# Altium schematic sheet 07_FRONT_PANEL_CONTROL.SchDoc(07_FRONT_PANEL_CONTROL)
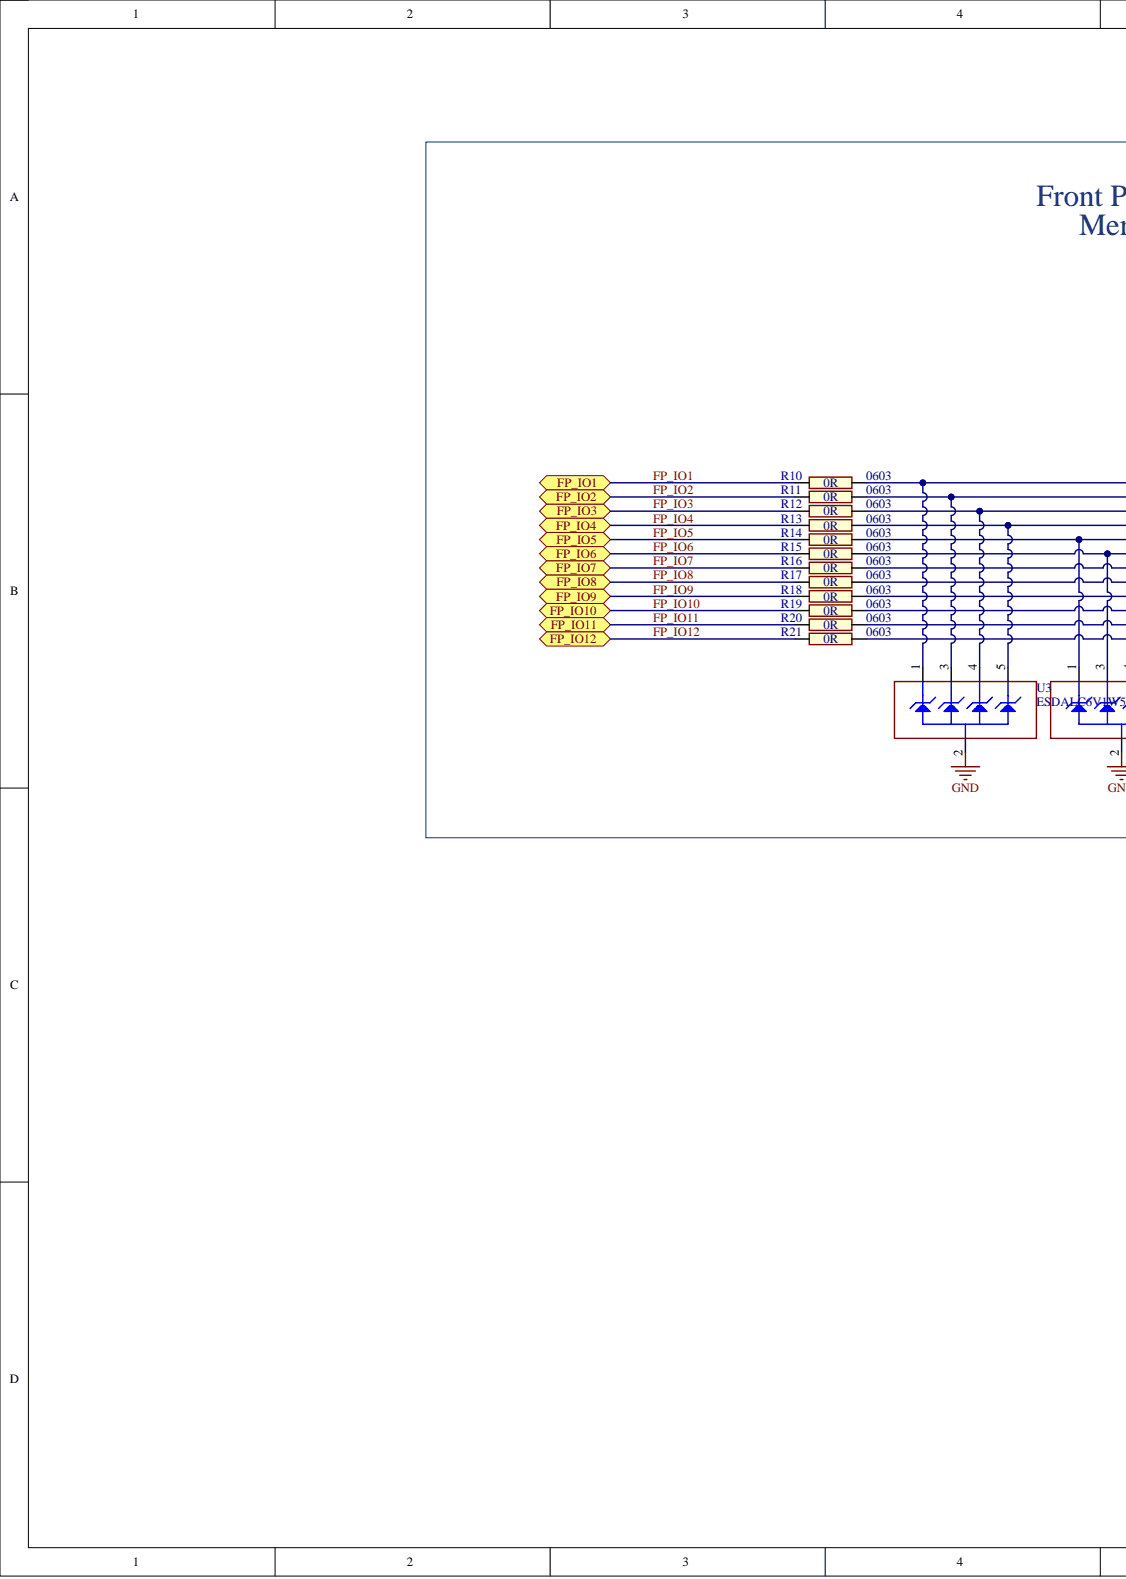
[diagram p0: 07_FRONT_PANEL_CONTROL.SchDoc, left]
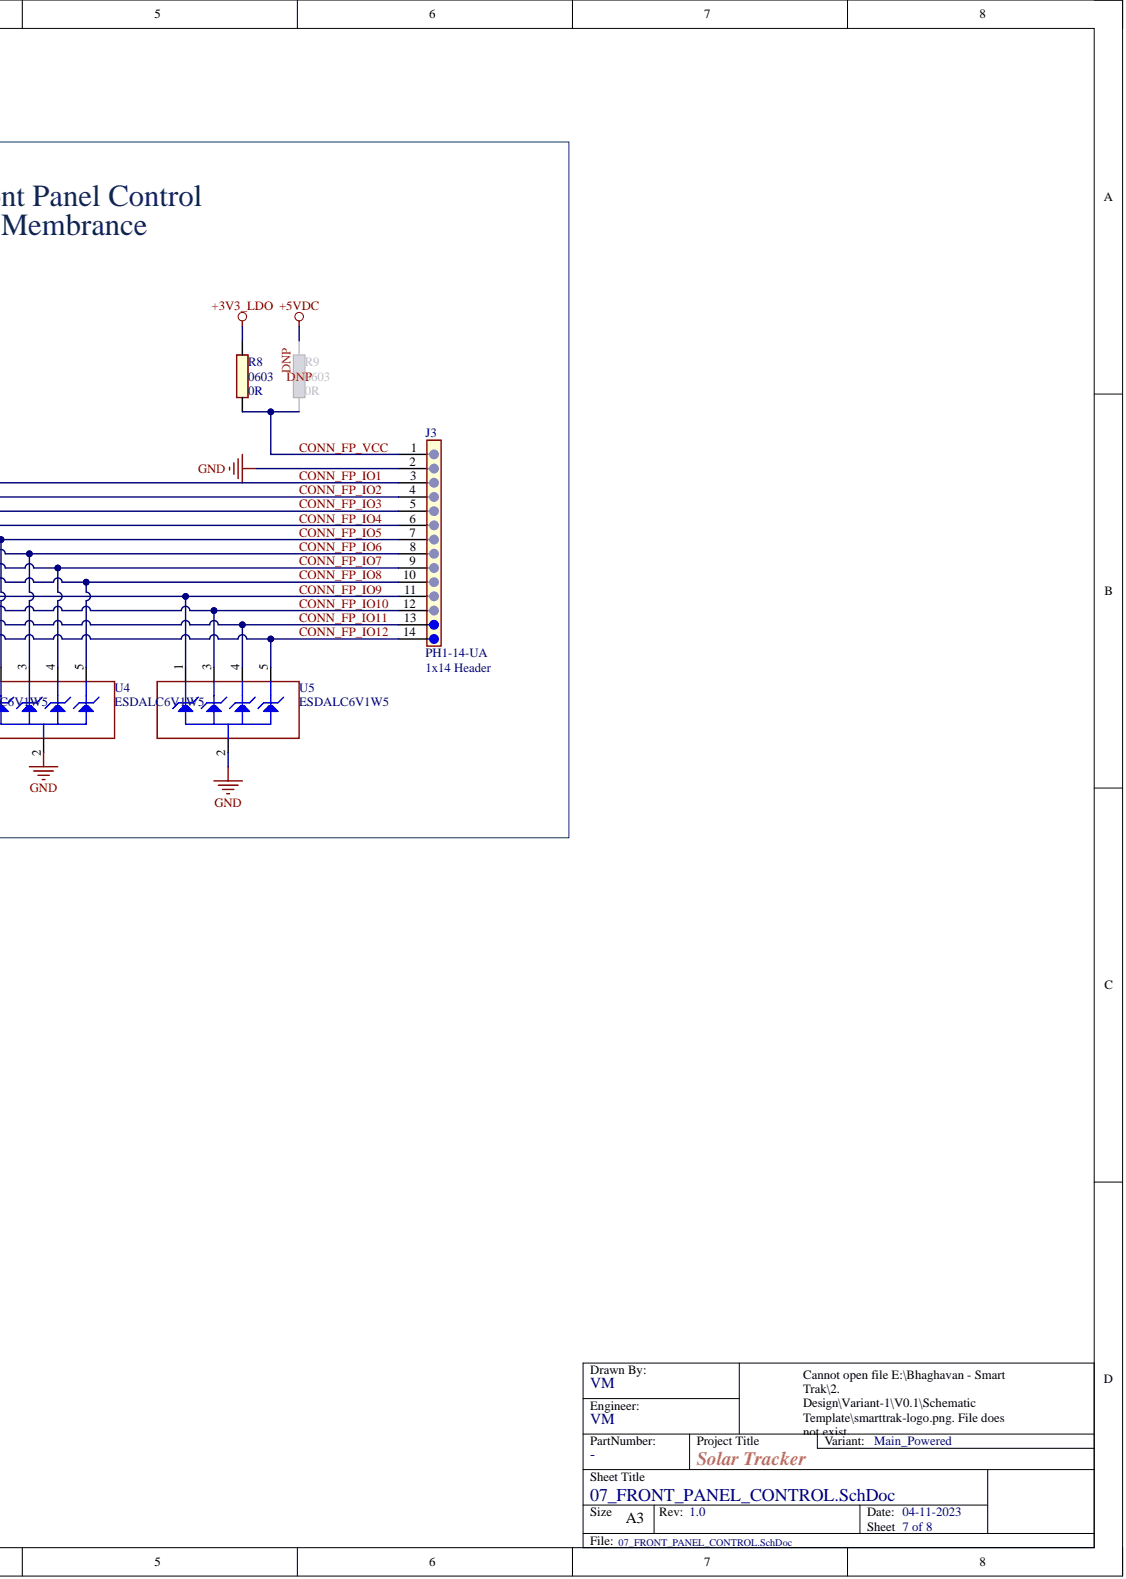
[diagram p1: 07_FRONT_PANEL_CONTROL.SchDoc, right]

## Parts
J3  value PH1-14-UA  Manufacturer: PH1-14-UA  Note: 1x14 Header  [BERG_1X14]  (hidden comment: HEADER_1x14_2.54MM)
R8  value 0R  Note: 0603  [R0603]  (hidden comment: RES_0R_0603)
R9  value 0R  Note: 0603  [R0603]  (hidden comment: RES_0R_0603)
R10  value 0R  Note: 0603  [R0603]  (hidden comment: RES_0R_0603)
R11  value 0R  Note: 0603  [R0603]  (hidden comment: RES_0R_0603)
R12  value 0R  Note: 0603  [R0603]  (hidden comment: RES_0R_0603)
R13  value 0R  Note: 0603  [R0603]  (hidden comment: RES_0R_0603)
R14  value 0R  Note: 0603  [R0603]  (hidden comment: RES_0R_0603)
R15  value 0R  Note: 0603  [R0603]  (hidden comment: RES_0R_0603)
R16  value 0R  Note: 0603  [R0603]  (hidden comment: RES_0R_0603)
R17  value 0R  Note: 0603  [R0603]  (hidden comment: RES_0R_0603)
R18  value 0R  Note: 0603  [R0603]  (hidden comment: RES_0R_0603)
R19  value 0R  Note: 0603  [R0603]  (hidden comment: RES_0R_0603)
R20  value 0R  Note: 0603  [R0603]  (hidden comment: RES_0R_0603)
R21  value 0R  Note: 0603  [R0603]  (hidden comment: RES_0R_0603)
U3  value ESDALC6V1W5  MPN: ESDALC6V1W5  [SOT65P210X110-5N]  (hidden comment: TVS_ESDALC6V1W5)
U4  value ESDALC6V1W5  MPN: ESDALC6V1W5  [SOT65P210X110-5N]  (hidden comment: TVS_ESDALC6V1W5)
U5  value ESDALC6V1W5  MPN: ESDALC6V1W5  [SOT65P210X110-5N]  (hidden comment: TVS_ESDALC6V1W5)

## Ports
FP_IO1, FP_IO2, FP_IO3, FP_IO4, FP_IO5, FP_IO6, FP_IO7, FP_IO8, FP_IO9, FP_IO10, FP_IO11, FP_IO12

## Nets
+3V3_LDO: R8.2
+5VDC: R9.2
GND: J3.2 U3.2 U4.2 U5.2
CONN_FP_IO1: J3.3 R10.2 U3.1
CONN_FP_IO2: J3.4 R11.2 U3.3
CONN_FP_IO3: J3.5 R12.2 U3.4
CONN_FP_IO4: J3.6 R13.2 U3.5
CONN_FP_IO5: J3.7 R14.2 U4.1
CONN_FP_IO6: J3.8 R15.2 U4.3
CONN_FP_IO7: J3.9 R16.2 U4.4
CONN_FP_IO8: J3.10 R17.2 U4.5
CONN_FP_IO9: J3.11 R18.2 U5.1
CONN_FP_IO10: J3.12 R19.2 U5.3
CONN_FP_IO11: J3.13 R20.2 U5.4
CONN_FP_IO12: J3.14 R21.2 U5.5
CONN_FP_VCC: J3.1 R8.1 R9.1
FP_IO1: R10.1 ; port FP_IO1
FP_IO2: R11.1 ; port FP_IO2
FP_IO3: R12.1 ; port FP_IO3
FP_IO4: R13.1 ; port FP_IO4
FP_IO5: R14.1 ; port FP_IO5
FP_IO6: R15.1 ; port FP_IO6
FP_IO7: R16.1 ; port FP_IO7
FP_IO8: R17.1 ; port FP_IO8
FP_IO9: R18.1 ; port FP_IO9
FP_IO10: R19.1 ; port FP_IO10
FP_IO11: R20.1 ; port FP_IO11
FP_IO12: R21.1 ; port FP_IO12

## Library data of the parts
J3 = HEADER_1x14_2.54MM: CONN HEADER VERT 14POS 2.54MM; MPN: Adam Tech; Component Kind: Standard; Library Name: Project.SchLib
R8-R21 = RES_0R_0603: RES SMD 0 OHM JUMPER 1/10W 0603; Manufacturer: Yageo; MPN: RC0603JR-070RL; Component Kind: Standard; Library Name: Project.SchLib
U3-U5 = TVS_ESDALC6V1W5: TVS DIODE 3VWM SOT323-5; Manufacturer: STMicroelectronics; Component Kind: Standard; ComponentLink1Description: Datasheet; ComponentLink1URL: http://tunmcdsvn.tun.st.com/Component_Datasheet_Library/Trunk/Diodes/STM_ESD_ESDALC6V1W5; Library Name: Project.SchLib
